# Altium schematic sheet 03-uHeader_JX1.SchDoc(03-uHeader_JX1)
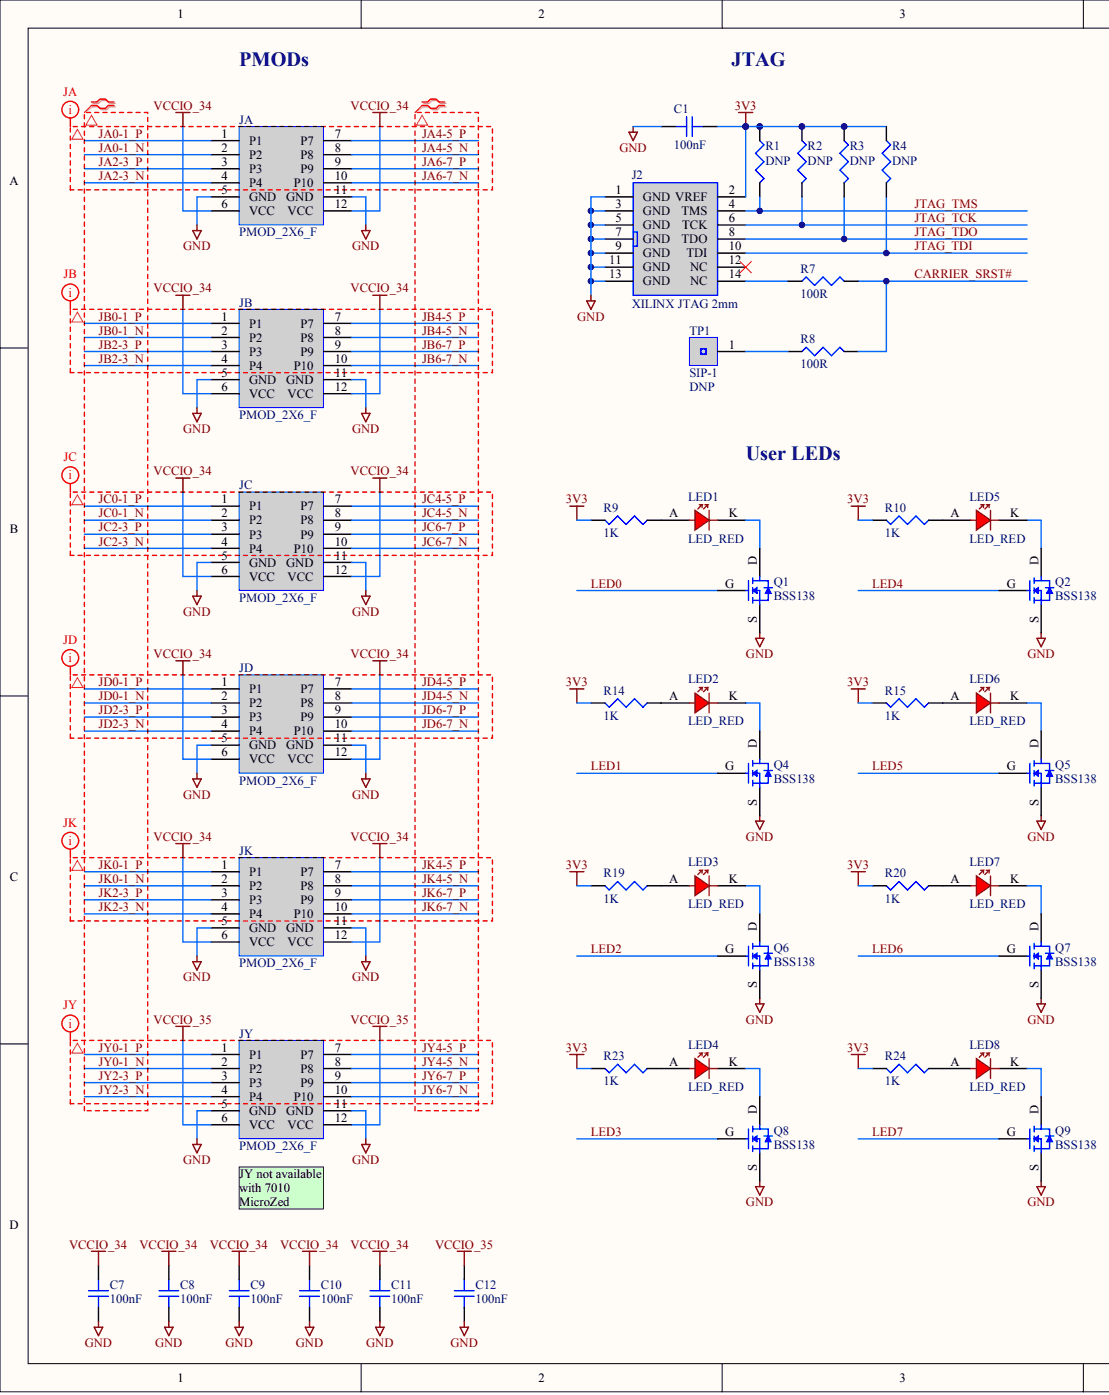
[diagram p0: 03-uHeader_JX1.SchDoc, left]
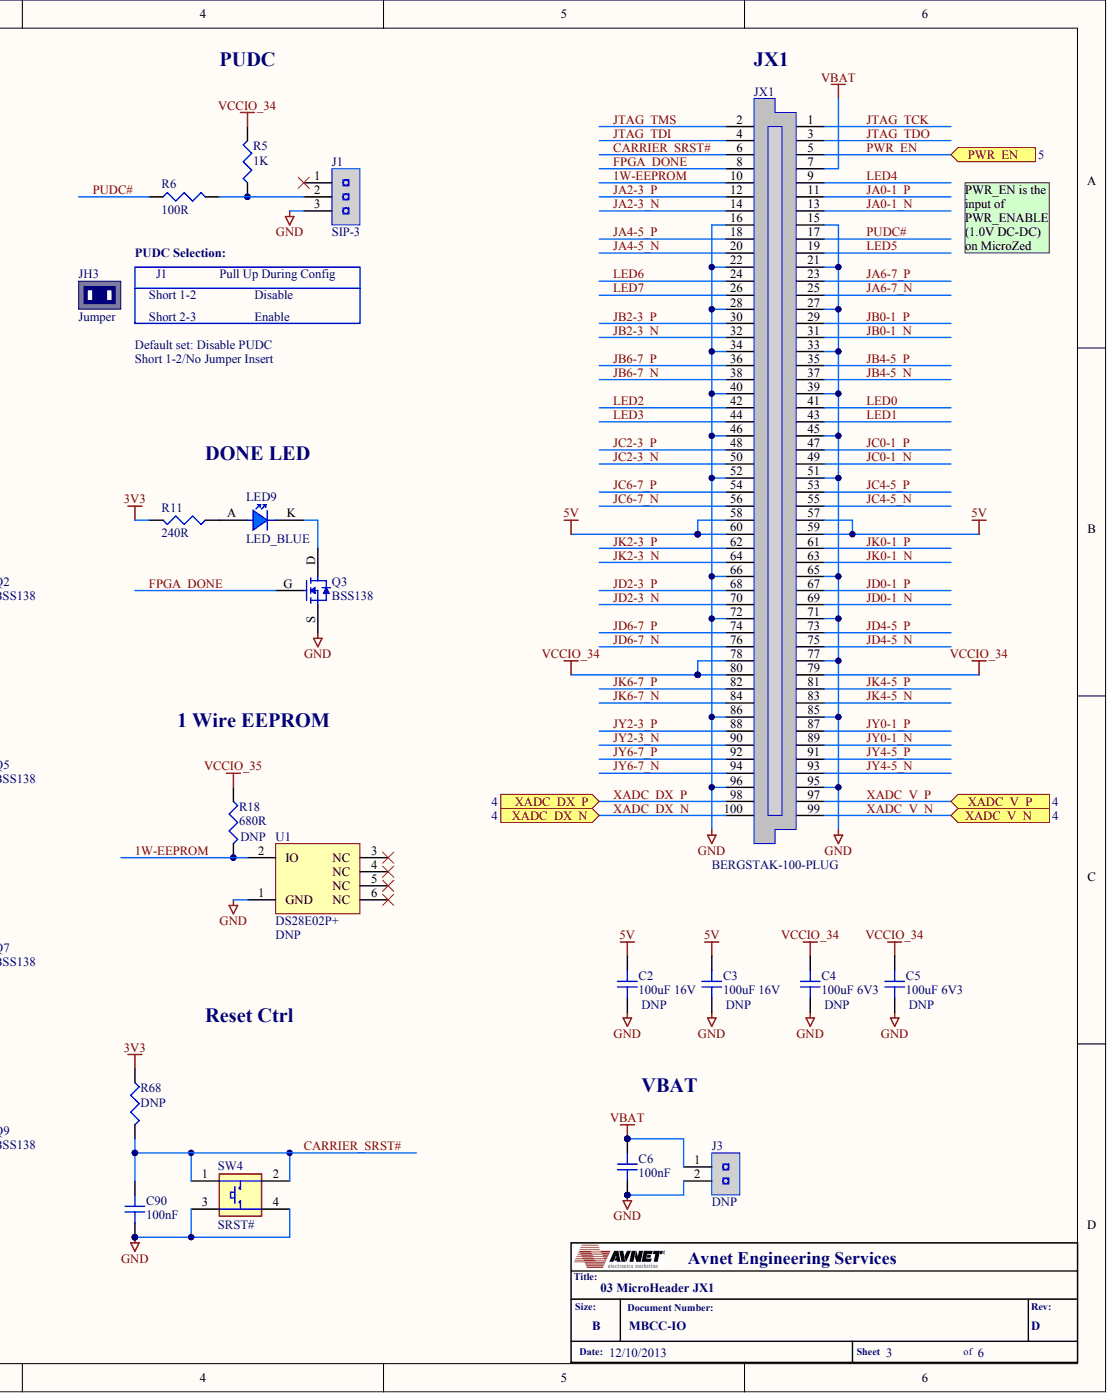
[diagram p1: 03-uHeader_JX1.SchDoc, right]

## Parts
C1  100nF
C2  100uF 16V
C3  100uF 16V
C4  100uF 6V3
C5  100uF 6V3
C6  100nF
C7  100nF
C8  100nF
C9  100nF
C10  100nF
C11  100nF
C12  100nF
C90  100nF
J1  SIP-3
J2  XILINX JTAG 2mm
J3  DNP
JA  PMOD_2X6_F
JB  PMOD_2X6_F
JC  PMOD_2X6_F
JD  PMOD_2X6_F
JH3  Jumper
JK  PMOD_2X6_F
JX1  BERGSTAK-100-PLUG
JY  PMOD_2X6_F
LED1  LED_RED
LED2  LED_RED
LED3  LED_RED
LED4  LED_RED
LED5  LED_RED
LED6  LED_RED
LED7  LED_RED
LED8  LED_RED
LED9  LED_BLUE
Q1  BSS138
Q2  BSS138
Q3  BSS138
Q4  BSS138
Q5  BSS138
Q6  BSS138
Q7  BSS138
Q8  BSS138
Q9  BSS138
R1  DNP
R2  DNP
R3  DNP
R4  DNP
R5  1K
R6  100R
R7  100R
R8  100R
R9  1K
R10  1K
R11  240R
R14  1K
R15  1K
R18  680R
R19  1K
R20  1K
R23  1K
R24  1K
R68  DNP
SW4  SRST#
TP1  SIP-1
U1  DS28E02P+

## Ports
PWR_EN, XADC_DX_N, XADC_DX_P, XADC_V_N, XADC_V_P

## Nets
3V3: C1.2 J2.2(VREF) R1.1 R2.1 R3.1 R4.1 R9.2 R10.2 R11.2 R14.2 R15.2 R19.2 R20.2 R23.2 R24.2 R68.1
5V: C2.1 C3.1 JX1.57 JX1.58 JX1.59 JX1.60
GND: C1.1 C2.2 C3.2 C4.2 C5.2 C6.2 C7.2 C8.2 C9.2 C10.2 C11.2 C12.2 C90.2 J1.3 J2.1(GND) J2.3(GND) J2.5(GND) J2.7(GND) J2.9(GND) J2.11(GND) J2.13(GND) J3.2 JA.5(GND) JA.11(GND) JB.5(GND) JB.11(GND) JC.5(GND) JC.11(GND) JD.5(GND) JD.11(GND) JK.5(GND) JK.11(GND) JX1.15 JX1.16 JX1.21 JX1.22 JX1.27 JX1.28 JX1.33 JX1.34 JX1.39 JX1.40 JX1.45 JX1.46 JX1.51 JX1.52 JX1.65 JX1.66 JX1.71 JX1.72 JX1.77 JX1.85 JX1.86 JX1.95 JX1.96 JY.5(GND) JY.11(GND) Q1.S Q2.S Q3.S Q4.S Q5.S Q6.S Q7.S Q8.S Q9.S SW4.3 SW4.4 U1.1(GND)
VBAT: C6.1 J3.1 JX1.7
VCCIO_34: C4.1 C5.1 C7.1 C8.1 C9.1 C10.1 C11.1 JA.6(VCC) JA.12(VCC) JB.6(VCC) JB.12(VCC) JC.6(VCC) JC.12(VCC) JD.6(VCC) JD.12(VCC) JK.6(VCC) JK.12(VCC) JX1.78 JX1.79 JX1.80 R5.2
VCCIO_35: C12.1 JY.6(VCC) JY.12(VCC) R18.1
1W-EEPROM: JX1.10 R18.2 U1.2(IO)
CARRIER_SRST#: C90.1 JX1.6 R7.2 R8.2 R68.2 SW4.1 SW4.2
FPGA_DONE: JX1.8 Q3.G
JA0-1_N: JA.2(P2) JX1.13
JA0-1_P: JA.1(P1) JX1.11
JA2-3_N: JA.4(P4) JX1.14
JA2-3_P: JA.3(P3) JX1.12
JA4-5_N: JA.8(P8) JX1.20
JA4-5_P: JA.7(P7) JX1.18
JA6-7_N: JA.10(P10) JX1.25
JA6-7_P: JA.9(P9) JX1.23
JB0-1_N: JB.2(P2) JX1.31
JB0-1_P: JB.1(P1) JX1.29
JB2-3_N: JB.4(P4) JX1.32
JB2-3_P: JB.3(P3) JX1.30
JB4-5_N: JB.8(P8) JX1.37
JB4-5_P: JB.7(P7) JX1.35
JB6-7_N: JB.10(P10) JX1.38
JB6-7_P: JB.9(P9) JX1.36
JC0-1_N: JC.2(P2) JX1.49
JC0-1_P: JC.1(P1) JX1.47
JC2-3_N: JC.4(P4) JX1.50
JC2-3_P: JC.3(P3) JX1.48
JC4-5_N: JC.8(P8) JX1.55
JC4-5_P: JC.7(P7) JX1.53
JC6-7_N: JC.10(P10) JX1.56
JC6-7_P: JC.9(P9) JX1.54
JD0-1_N: JD.2(P2) JX1.69
JD0-1_P: JD.1(P1) JX1.67
JD2-3_N: JD.4(P4) JX1.70
JD2-3_P: JD.3(P3) JX1.68
JD4-5_N: JD.8(P8) JX1.75
JD4-5_P: JD.7(P7) JX1.73
JD6-7_N: JD.10(P10) JX1.76
JD6-7_P: JD.9(P9) JX1.74
JK0-1_N: JK.2(P2) JX1.63
JK0-1_P: JK.1(P1) JX1.61
JK2-3_N: JK.4(P4) JX1.64
JK2-3_P: JK.3(P3) JX1.62
JK4-5_N: JK.8(P8) JX1.83
JK4-5_P: JK.7(P7) JX1.81
JK6-7_N: JK.10(P10) JX1.84
JK6-7_P: JK.9(P9) JX1.82
JTAG_TCK: J2.6(TCK) JX1.1 R2.2
JTAG_TDI: J2.10(TDI) JX1.4 R4.2
JTAG_TDO: J2.8(TDO) JX1.3 R3.2
JTAG_TMS: J2.4(TMS) JX1.2 R1.2
JY0-1_N: JX1.89 JY.2(P2)
JY0-1_P: JX1.87 JY.1(P1)
JY2-3_N: JX1.90 JY.4(P4)
JY2-3_P: JX1.88 JY.3(P3)
JY4-5_N: JX1.93 JY.8(P8)
JY4-5_P: JX1.91 JY.7(P7)
JY6-7_N: JX1.94 JY.10(P10)
JY6-7_P: JX1.92 JY.9(P9)
LED0: JX1.41 Q1.G
LED1: JX1.43 Q4.G
LED2: JX1.42 Q6.G
LED3: JX1.44 Q8.G
LED4: JX1.9 Q2.G
LED5: JX1.19 Q5.G
LED6: JX1.24 Q7.G
LED7: JX1.26 Q9.G
PUDC#: JX1.17 R6.1
PWR_EN: JX1.5 ; port PWR_EN
XADC_DX_N: JX1.100 ; port XADC_DX_N
XADC_DX_P: JX1.98 ; port XADC_DX_P
XADC_V_N: JX1.99 ; port XADC_V_N
XADC_V_P: JX1.97 ; port XADC_V_P
NetJ1_2: J1.2 R5.1 R6.2
NetJ2_14: J2.14(NC) R7.1
NetLED1_A: LED1.A R9.1
NetLED1_K: LED1.K Q1.D
NetLED2_A: LED2.A R14.1
NetLED2_K: LED2.K Q4.D
NetLED3_A: LED3.A R19.1
NetLED3_K: LED3.K Q6.D
NetLED4_A: LED4.A R23.1
NetLED4_K: LED4.K Q8.D
NetLED5_A: LED5.A R10.1
NetLED5_K: LED5.K Q2.D
NetLED6_A: LED6.A R15.1
NetLED6_K: LED6.K Q5.D
NetLED7_A: LED7.A R20.1
NetLED7_K: LED7.K Q7.D
NetLED8_A: LED8.A R24.1
NetLED8_K: LED8.K Q9.D
NetLED9_A: LED9.A R11.1
NetLED9_K: LED9.K Q3.D
NetR8_1: R8.1 TP1.1
N00101:
N00102:
N00103:
N00104:
N00105:
N00106:
N00107:
N00108:

Not connected: J1 1; J2 12(NC); U1 3(NC) 4(NC) 5(NC) 6(NC)

## Library data of the parts
C1 C6-C12 C90 = (no symbol name): MFG#1/Part#: AVX:06035C104KAT2A
C2 C3 = (no symbol name): MFG#1/Part#: TYC: EMK325ABJ107MM-T; DNP: DNP
C4 C5 = (no symbol name): MFG#1/Part#: muRata: GRM32EF50J107ZE20K; DNP: DNP
J1 = (no symbol name): MFG#1/Part#: TE: 5-146280-3; MFG#B/Part#: Samtec: HTSW-103-08-G-S-RA
J2 = (no symbol name): MFG#A/Part#: Molex: 87831-1419; MFG#1/Part#: Molex: 87832-1420
J3 = (no symbol name): MFG#1/Part#: TE: 5-146280-2; MFG#B/Part#: Molex: 5267-2; DNP: DNP
JA JB JC JD JK JY = (no symbol name): MFG#B/Part#: TE: 5-147105-6; MFG#1/Part#: SAMTEC: SSW-106-02-F-D-RA
JH3 = (no symbol name): MFG#1/Part#: 3M: 969102-0000-DA
JX1 = (no symbol name): MFG#1/Part#: FCI: 61083-101400LF
LED1-LED8 = (no symbol name): MFG#1/Part#: LTP: LTST-C190CKT
LED9 = (no symbol name): MFG#1/Part#: LTP: LTST-C190TBKT
Q1-Q9 = (no symbol name): MFG#1/Part#: OnSemi: BSS138LT1G
R1-R4 R68 = (no symbol name): MFG#1/Part#: Vishay: CRCW0603xxxxJNEA; DNP: DNP
R5 R9 R10 R14 R15 R19 R20 R23 R24 = (no symbol name): MFG#1/Part#: Vishay: CRCW06031K00JNEA
R6-R8 = (no symbol name): MFG#1/Part#: Vishay: CRCW0603100RJNEA
R11 = (no symbol name): MFG#1/Part#: Vishay: CRCW0603240RJNEA
R18 = (no symbol name): MFG#1/Part#: Vishay: CRCW0603680RJNEA; DNP: DNP
SW4 = (no symbol name): MFG#1/Part#: TE: 1825910-7 (FSM4JAH); MFG#B/Part#: TE: 4-1437565-1 (FSM4JSMA)
TP1 = (no symbol name): MFG#1/Part#: TE: 5-146280-1; DNP: DNP
U1 = (no symbol name): MFG#1/Part#: Maxim: DS28E02P+; DNP: DNP
